# Altium schematic sheet Shelly Power Systems.SchDoc
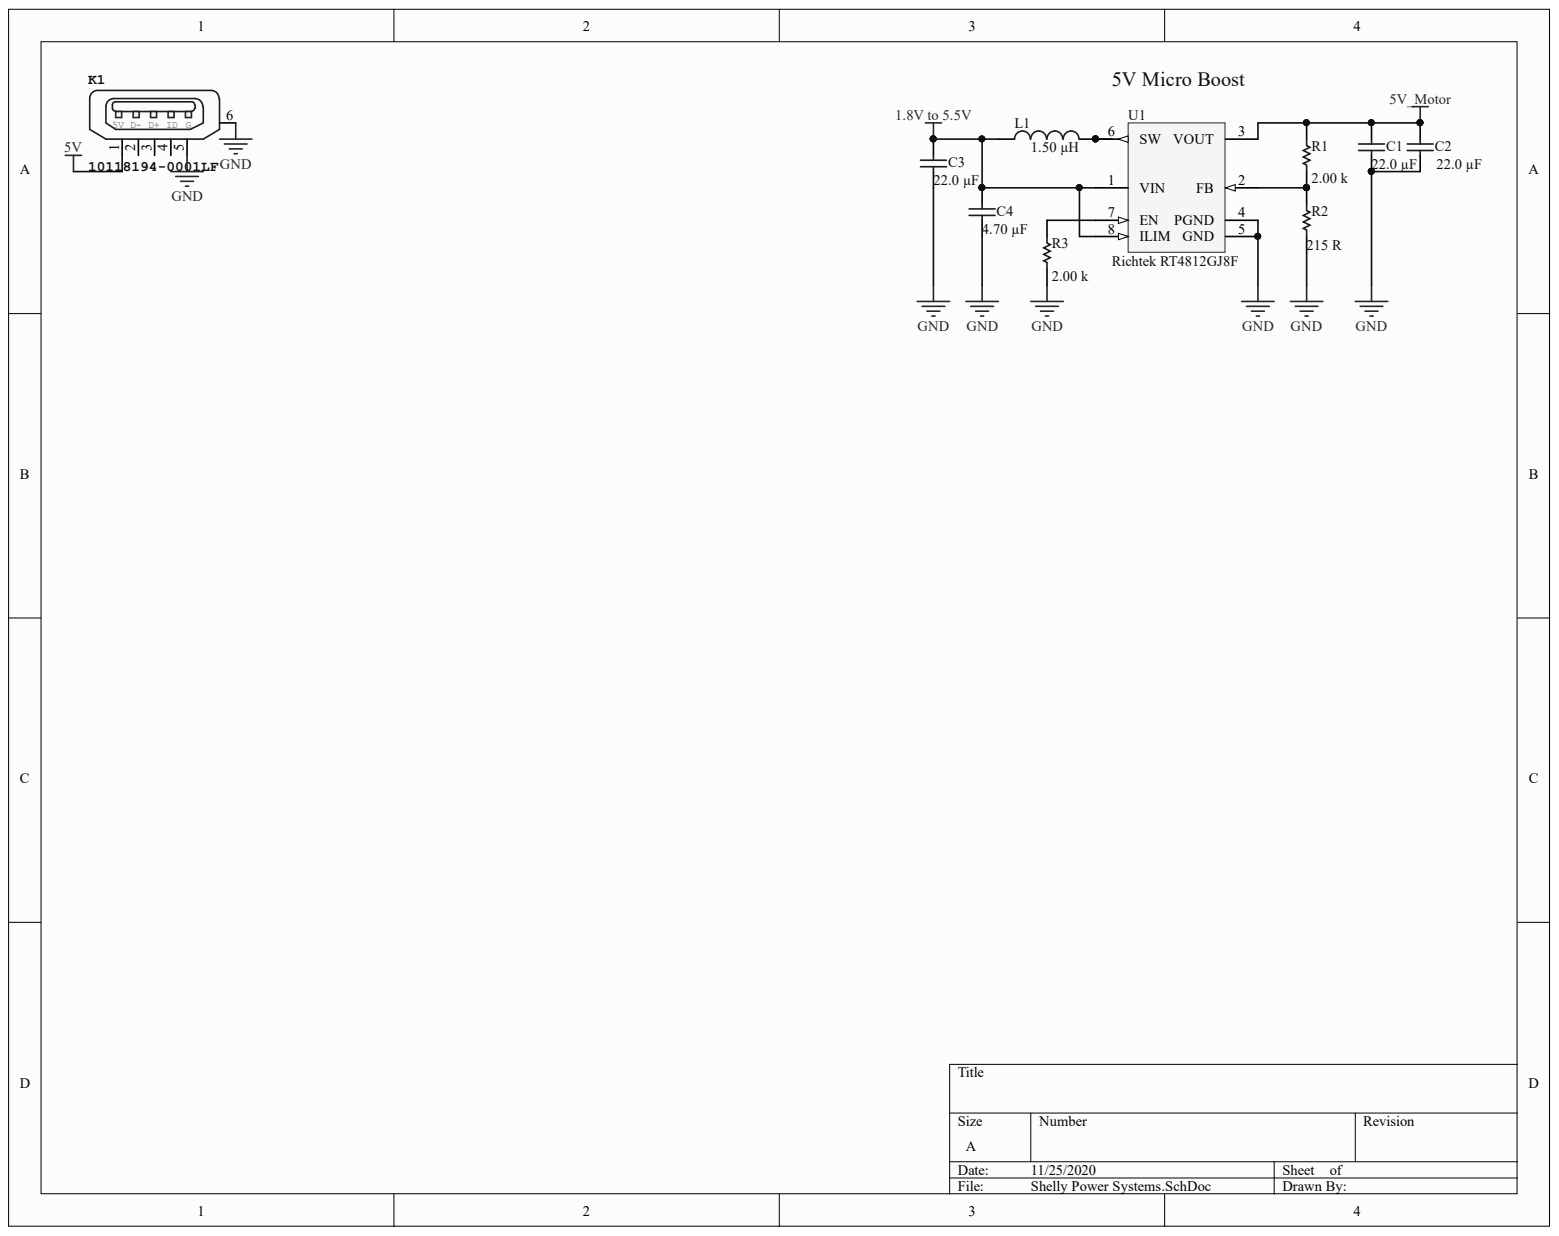
[diagram p0: Shelly Power Systems.SchDoc]

## Parts
C1  Samsung Electro-Mechanics CL21A226MOCLRNC  [CAPC2013X145X50ML20T25]  (value not drawn)
C2  capacitance: 22.0 µF  [CAPC2013X145X50ML20T25]  (hidden comment: Samsung Electro-Mechanics CL21A226MOCLRNC)
C3  Samsung Electro-Mechanics CL21A226MOCLRNC  [CAPC2013X145X50ML20T25]  (value not drawn)
C4  Capacitance: 4.70 µF  [PCB-4kws2ejwr5jtmehi4fny-1]  (hidden comment: Samsung CL10A475MQ8NNNC)
K1  10118194-0001LF  [USB 2.0 Micro B]
L1  Inductance: 1.50 µH  [PCB-ju97yutp2azoh42t42cd-1]  (hidden comment: Bourns SRN5040TA-1R5M)
R1  Stackpole Electronics RMCF0603FT2K00  [RES0603-IB]  (value not drawn)
R2  Resistance: 215 R  [RES0603-IB]  (hidden comment: Stackpole Electronics RMCF0603FT215R)
R3  Stackpole Electronics RMCF0603FT2K00  [RES0603-IB]  (value not drawn)
U1  Richtek RT4812GJ8F  [PCB-vikq55t1s2bdkemw8qqb-1]

## Nets
1.8V TO 5.5V: C3.2 C4.2 L1.1 U1.1(VIN) U1.8(ILIM)
5V: K1.1(5V)
5V_MOTOR: C1.2 C2.2 R1.1 U1.3(VOUT)
GND: C1.1 C2.1 C3.1 C4.1 K1.5(G) K1.6 R2.1 R3.2 U1.4(PGND) U1.5(GND)
NetL1_2: L1.2 U1.6(SW)
NetR1_2: R1.2 R2.2 U1.2(FB)
NetR3_1: R3.1 U1.7(EN)

Not connected: K1 2(D-) 3(D+) 4(ID)

## Library data of the parts
C1 C3 = CMP-5d55905194d98292-4: Cap Ceramic 22uF 16V X5R 20% SMD 0805 85°C Cardboard T/R; capacitance: 22.0 µF; lead-free status: Lead Free; capacitance tolerance: ±20%; case/package: 0805; Component Kind: Standard; dielectric characteristic: X5R; Library Name: CommunityVault; manufacturer part number: CL21A226MOCLRNC; rohs: Compliant; Supplier part number: 1276-6780-1-ND; voltage rating (dc): 16V
C2 = CMP-5d55905194d98292-4: Cap Ceramic 22uF 16V X5R 20% SMD 0805 85°C Cardboard T/R; lead-free status: Lead Free; capacitance tolerance: ±20%; case/package: 0805; Component Kind: Standard; dielectric characteristic: X5R; Library Name: CommunityVault; manufacturer part number: CL21A226MOCLRNC; rohs: Compliant; Supplier part number: 1276-6780-1-ND; voltage rating (dc): 16V
C4 = CMP-044acc6ebbc7db8d-2: CL10 Series 4.7 uF 6.3 V ±20 % Tolerance X5R SMT Multilayer Ceramic Capacitor; Capacitance Tolerance: ±20%; Lead-Free Status: Lead Free; Voltage Rating (DC): 6.30 V; Case/Package: 0603; Component Kind: Standard; Dielectric Characteristic: X5R; Library Name: CommunityVault; Manufacturer Part Number: CL10A475MQ8NNNC; OctopartID: 044acc6ebbc7db8d; Octopart URL: https://octopart.com/cl10a475mq8nnnc-samsung-19831531; RoHS: Compliant
K1 = CMP-86f04ebedf8a5937-50: Single Port 5 Contact Horizontal Right Angle Micro B USB 2.0 Receptacle; Component Kind: Standard; Library Name: CommunityVault
L1 = CMP-7f15b3abae8ca0d2-1: Ind Power Wirewound 1.5uH 20% Ferrite Core T/R; Component Kind: Standard; Library Name: CommunityVault; Manufacturer Part Number: SRN5040TA-1R5M; OctopartID: 7f15b3abae8ca0d2; Octopart URL: https://octopart.com/srn5040ta-1r5m-bourns-95745279; RoHS: Compliant
R1 R3 = CMP-6b1da3326540b4da-2: Res Thick Film 0603 2K Ohm 1% 0.1W(1/10W) ±100ppm/C Pad SMD Automotive T/R; Lead-Free Status: Lead Free; Power Rating: 100 mW; Resistance: 2.00 k; Case/Package: 0603; Component Kind: Standard; Library Name: CommunityVault; Manufacturer Part Number: RMCF0603FT2K00; Mounting Style: Surface Mount; OctopartID: 6b1da3326540b4da; Octopart URL: https://octopart.com/rmcf0603ft2k00-stackpole+electronics-19213115; Packaging: Tape & Reel (TR); Resistance Tolerance: ±1%; RoHS: Compliant
R2 = CMP-af9267bda9bc7055-1: Res Thick Film 0603 215 Ohm 1% 0.1W(1/10W) ±100ppm/C Pad SMD Automotive T/R; Lead-Free Status: Lead Free; Power Rating: 100 mW; Case/Package: 0603; Component Kind: Standard; Library Name: CommunityVault; Manufacturer Part Number: RMCF0603FT215R; Mounting Style: Surface Mount; OctopartID: af9267bda9bc7055; Octopart URL: https://octopart.com/rmcf0603ft215r-stackpole+electronics-19211080; Packaging: Tape & Reel (TR); Resistance Tolerance: ±1%; RoHS: Compliant
U1 = CMP-0ea6c458bfc8d161-3: Boost Switching Regulator IC Positive Adjustable 1.8V 1 Output 2A SOT-23-8 Thin, TSOT-23-8; Frequency - Switching: 500kHz; Number of Outputs: 1; Category: Integrated Circuits (ICs); Component Kind: Standard; Cost: 1.56; Library Name: CommunityVault; Package / Case: SOT-23-8 Thin, TSOT-23-8
